# Altium schematic sheet Power_Distribution.SchDoc(Power_Distribution)
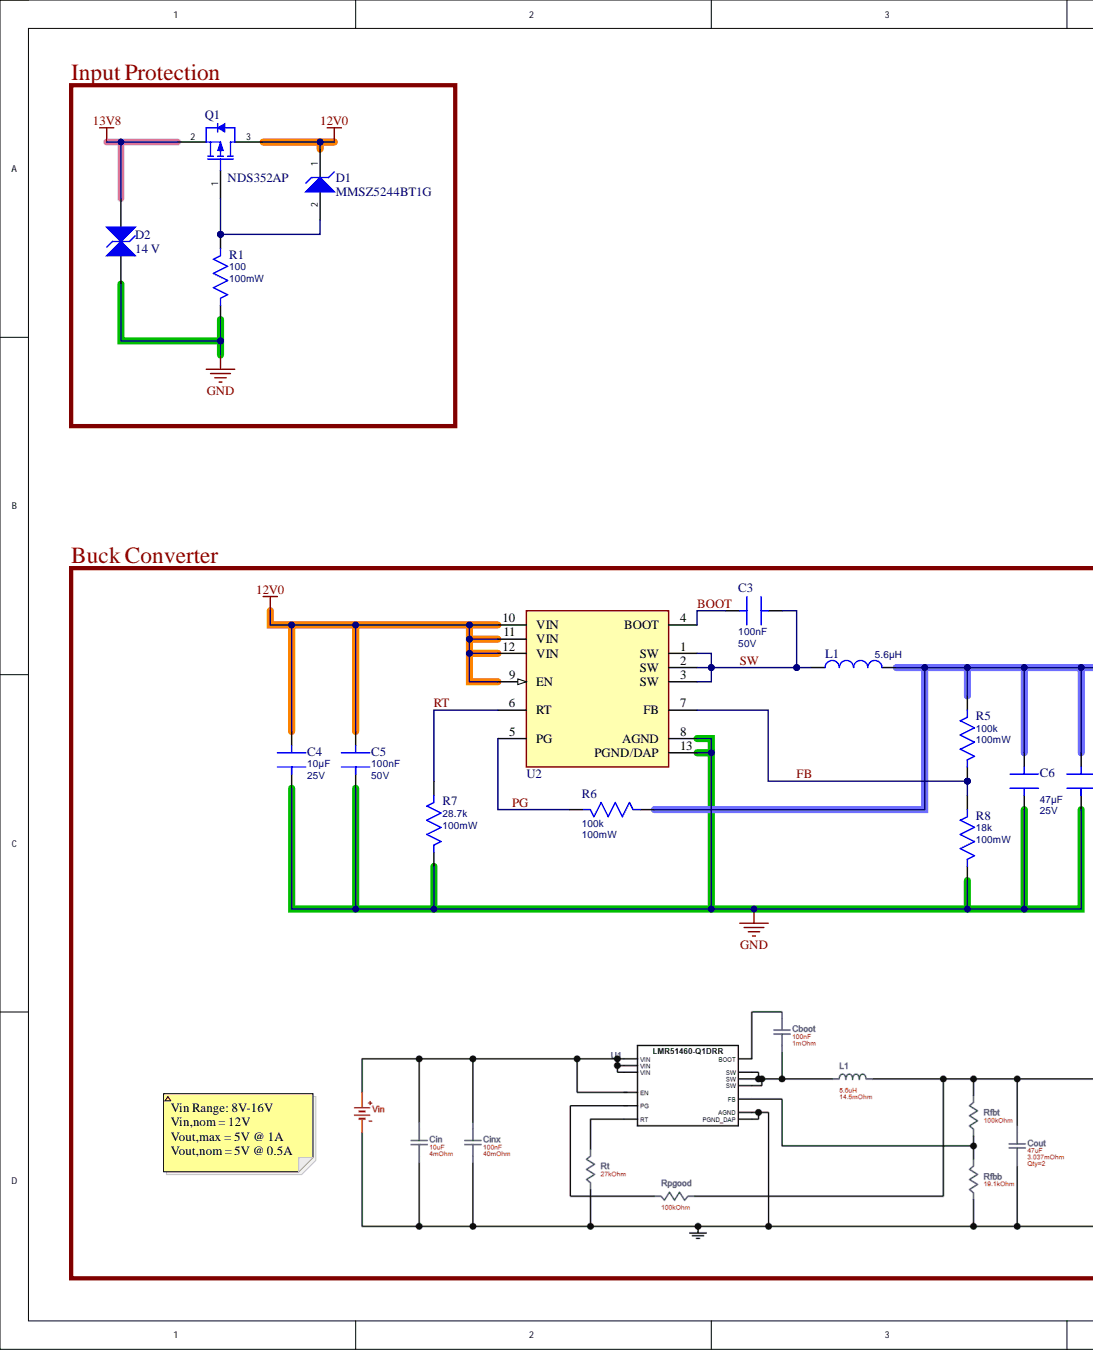
[diagram p0: Power_Distribution.SchDoc, left]
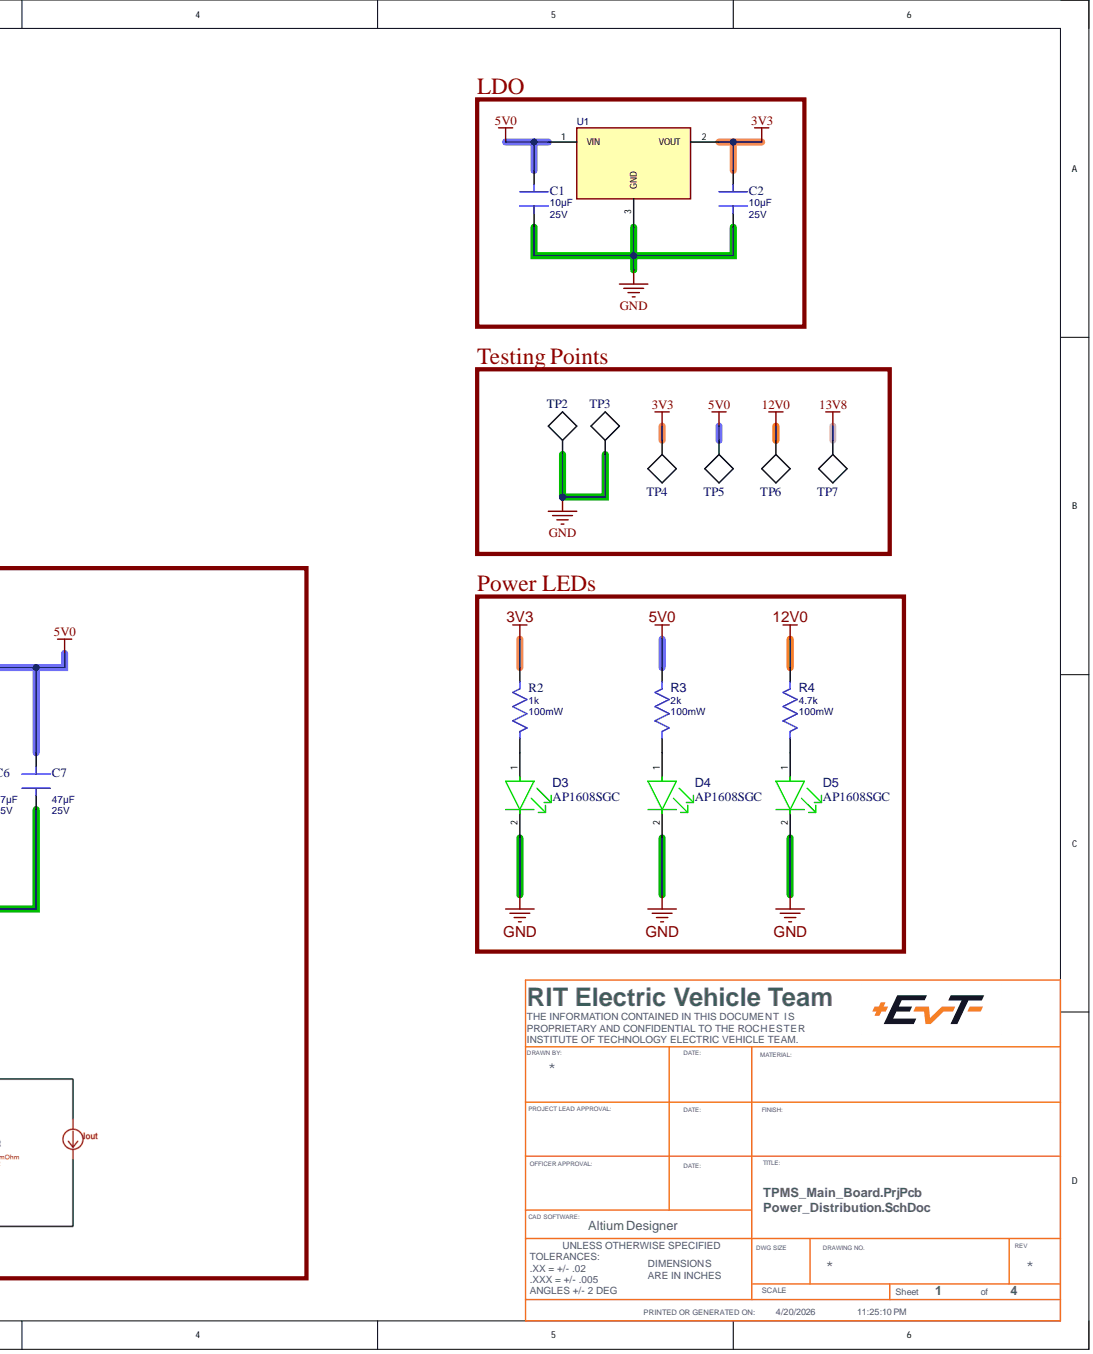
[diagram p1: Power_Distribution.SchDoc, right]

## Parts
C1  Capacitance: 10µF  Voltage Rating: 25V  (hidden comment: CAP 10uF 25V X5R 20% 0603)
C2  Capacitance: 10µF  Voltage Rating: 25V  (hidden comment: CAP 10uF 25V X5R 20% 0603)
C3  Capacitance: 100nF  Voltage Rating: 50V  (hidden comment: CAP 100nF 50V X7R 10% 0603 CAP)
C4  Capacitance: 10µF  Voltage Rating: 25V  (hidden comment: CAP 10uF 25V X5R 20% 0603)
C5  Capacitance: 100nF  Voltage Rating: 50V  (hidden comment: CAP 100nF 50V X7R 10% 0603 CAP)
C6  Capacitance: 47µF  Voltage Rating: 25V  (hidden comment: CAP 47U0 25V 20%)
C7  Capacitance: 47µF  Voltage Rating: 25V  (hidden comment: CAP 47U0 25V 20%)
D1  MMSZ5244BT1G
D2  Standoff Voltage: 14 V  (hidden comment: SMAJ14CA-E3/61)
D3  AP1608SGC
D4  AP1608SGC
D5  AP1608SGC
L1  Inductance: 5.6µH  (hidden comment: SRP6540-5R6M)
Q1  NDS352AP
R1  Power Rating: 100mW  (hidden comment: RES 100R 100mW 1%)
R2  Power Rating: 100mW  Resistance: 1k  (hidden comment: RES 1k 100m 0.1% 0603)
R3  Power Rating: 100mW  Resistance: 2k  (hidden comment: RES 2K 100mW 1%)
R4  Power Rating: 100mW  Resistance: 4.7k  (hidden comment: RES 4k7 100mW 1%)
R5  Power Rating: 100mW  Resistance: 100k  (hidden comment: RES 100k 100m 0.1% 0603)
R6  Power Rating: 100mW  Resistance: 100k  (hidden comment: RES 100k 100m 0.1% 0603)
R7  Power Rating: 100mW  Resistance: 28.7k  (hidden comment: RES 28k7 100mW 1%)
R8  Power Rating: 100mW  Resistance: 18k  (hidden comment: RES 18k 100m 1% 0603)
TP2  50mil TP  (value not drawn)
TP3  50mil TP  (value not drawn)
TP4  50mil TP  (value not drawn)
TP5  50mil TP  (value not drawn)
TP6  50mil TP  (value not drawn)
TP7  50mil TP  (value not drawn)
U1  AP7375-33SA-7  (value not drawn)
U2  LMR51460SQDRRRQ1  (value not drawn)

## Nets
3V3: C2.1 R2.1 TP4.1 U1.2
5V0: C1.1 C6.1 C7.1 L1.2 R3.1 R5.1 R6.2 TP5.1 U1.1
12V0: C4.1 C5.1 D1.1 Q1.3 R4.1 TP6.1 U2.9(EN) U2.10(VIN) U2.11(VIN) U2.12(VIN)
13V8: D2.2 Q1.2 TP7.1
GND: C1.2 C2.2 C4.2 C5.2 C6.2 C7.2 D2.1 D3.2 D4.2 D5.2 R1.2 R7.2 R8.2 TP2.1 TP3.1 U1.3 U2.8(AGND) U2.13(PGND/DAP)
BOOT: C3.1 U2.4(BOOT)
FB: R5.2 R8.1 U2.7(FB)
PG: R6.1 U2.5(PG)
RT: R7.1 U2.6(RT)
SW: C3.2 L1.1 U2.1(SW) U2.2(SW) U2.3(SW)
NetD1_2: D1.2 Q1.1 R1.1
NetD3_1: D3.1 R2.2
NetD4_1: D4.1 R3.2
NetD5_1: D5.1 R4.2

## Library data of the parts
C1 C2 C4 = CMP-00654-1: Multilayer Ceramic Capacitors MLCC – SMD/SMT; TDK; C1608X5R1E106M080AC; 10 µF; 0603; 25 V; 25 V; Polarized (Y/N): N; Component Kind: Standard; JLC Basic Part (Y/N): N; JLC Part #: C2168289; MPN: C1608X5R1E106M080AC; Package: 0603; Temperature Coefficient: X5R; Tolerance: 20%; Library Name: RIT-EVT-Vault
C3 C5 = CMP-00408-16: 50V 100nF X7R ±10% 0603 Multilayer Ceramic Capacitors MLCC - SMD/SMT ROHS; MPN: CC0603KRX7R9BB104; Polarized (Y/N): N; Component Kind: Standard; JLC Basic Part (Y/N): Y; JLC Part #: C14663; Package: 0603; Temperature Coefficient: X7R; Tolerance: 10%; Library Name: RIT-EVT-Vault
C6 C7 = CMP-00684-2: Multilayer Ceramic Capacitors MLCC – SMD/SMT; TDK; C3216X5R1E476M160AC; 47 µF; 1206; 25 V; 25 V; Polarized (Y/N): N; Component Kind: Standard; JLC Basic Part (Y/N): N; JLC Part #: C2167787; MPN: C3216X5R1E476M160AC; Package: 1206; Temperature Coefficient: N/A; Tolerance: 20%; Library Name: RIT-EVT-Vault
D1 = CMP-00560-2: Diode Zener Single 14V 5% 500mW Automotive 2-Pin SOD-123 T/R; Current Rating: 9mA; Forward Voltage: 900mV; Component Kind: Standard; JLC Basic Part (Y/N): N; JLCPCB Part #: C242286; MPN: MMSZ5244BT1G; Package: SOD-123; Zener Voltage: 14V; Library Name: RIT-EVT-Vault
D2 = CMP-00583-1: SMAJ Series 400 W 14 V Bi-Directional SMT TRANSZORB® TVS Diode - DO-214AC; Breakdown Voltage: 15.6V; Clamping Voltage: 23.2V; Current Rating: 17.2 A; Component Kind: Standard; JLC Basic Part (Y/N): N; JLCPCB Part #: C250392; MPN: SMAJ14CA-E3/61; Package: SMA(DO-214AC); Library Name: RIT-EVT-Vault
D3-D5 = CMP-00486-2: LED Uni-Color Green 565nm 2-Pin Chip LED / 1.6X0.8mm SMD CHIP LED LAMP; Current Rating: 25mA; Forward Voltage: 2.5V; Reverse Breakdown Voltage: 5V; Wavelength: 568nm; Color: Green; Component Kind: Standard; JLC Basic Part (Y/N): N; JLCPCB Part #: C9900169279; MPN: AP1608SGC; Package: 0603; Library Name: RIT-EVT-Vault
L1 = CMP-00685-1: Inductor Power Shielded/Molded Wirewound 5.6uH 20% 100KHz Powdered Iron 5.5A 38mOhm DCR T/R; Core Material: Ferrite; ESR: 38m; Polarized (Y/N): N; Saturation Current: 6A; Component Kind: Standard; Heating Current: 5.5A; JLC Basic Part (Y/N): N; JLC Part #: C2041376; MPN: SRP6540-5R6M; Package: Nonstandard; SRF: 100kHz; Tolerance: 20%; Library Name: RIT-EVT-Vault
Q1 = CMP-00492-1: P-Channel Logic Level Enhancement Mode Field Effect Transistor -30V, -0.9A, 300mΩ; Rated Drain/Collector Current: 900mA; Transistor Type: P; Component Kind: Standard; JLC Basic Part: N; JLCPCB Part: C3290037; JLCPCB Part #: C3290037; MPN: NDS352AP; Package: SuperSOT-7; Rated Drain-Source or Collector-Emitter Voltage: -30V; Library Name: RIT-EVT-Vault
R1 = CMP-00434-3: -55℃~+155℃ 100mW 100Ω 75V Thick Film Resistor ±1% ±100ppm/℃ 0603 Chip Resistor - Surface Mount ROHS; MPN: CRCW0603100RFKEA; Polarized (Y/N): N; Resistance: 100; Component Kind: Standard; JLC Basic Part (Y/N): Y; JLCPCB Part #: C22775; Package: 0603; Tolerance: 1%; Voltage Rating: 75V; Library Name: RIT-EVT-Vault
R2 = CMP-00544-3: -55℃~+155℃ 100mW 1kΩ 75V Thin Film Resistor ±0.1% ±25ppm/℃ 0603 Chip Resistor - Surface Mount ROHS; MPN: RT0603BRD071KL; Polarized (Y/N): N; Component Kind: Standard; JLC Basic Part (Y/N): N; JLCPCB Part #: C110776; Package: 0603; Tolerance: 0.1%; Voltage Rating: 75V; Library Name: RIT-EVT-Vault
R3 = CMP-00474-1: -55℃~+155℃ 100mW 2kΩ 75V Thick Film Resistor ±1% ±100ppm/℃ 0603 Chip Resistor - Surface Mount ROHS; MPN: 0603WAF2001T5E; Polarized (Y/N): N; Component Kind: Standard; JLC Basic Part (Y/N): Y; JLCPCB Part #: C22975; Package: 0603; Tolerance: 1%; Voltage Rating: 75V; Library Name: RIT-EVT-Vault
R4 = CMP-00425-4: -55℃~+155℃ 100mW 4.7kΩ 75V Thick Film Resistor ±1% ±100ppm/℃ 0603 Chip Resistor - Surface Mount ROHS; MPN: 0603WAF4701T5E; Polarized (Y/N): N; Component Kind: Standard; JLC Basic Part (Y/N): N; JLCPCB Part #: C23162; Package: 0603; Tolerance: 1%; Voltage Rating: 75V; Library Name: RIT-EVT-Vault
R5 R6 = CMP-00538-2: -55℃~+155℃ 100kΩ 100mW 75V Thin Film Resistor ±0.1% ±25ppm/℃ 0603 Chip Resistor - Surface Mount ROHS; MPN: RT0603BRD07100KL; Polarized (Y/N): N; Component Kind: Standard; JLC Basic Part (Y/N): N; JLCPCB Part #: C122538; Package: 0603; Tolerance: 0.1%; Voltage Rating: 75V; Library Name: RIT-EVT-Vault
R7 = CMP-00610-2: 100mW Thick Film Resistors 75V ±100ppm/℃ ±1% 28.7kΩ 0603 Chip Resistor - Surface Mount ROHS; MPN: 0603WAF2872T5E; Polarized (Y/N): N; Component Kind: Standard; JLC Basic Part (Y/N): N; JLCPCB Part #: C22928; Package: 0603; Tolerance: 1%; Voltage Rating: 75V; Library Name: RIT-EVT-Vault
R8 = CMP-00557-2: -55℃~+155℃ 100mW 18kΩ 75V Thick Film Resistor ±1% ±100ppm/℃ 0603 Chip Resistor - Surface Mount ROHS; MPN: 0603WAF1802T5E; Polarized (Y/N): N; Component Kind: Standard; JLC Basic Part (Y/N): Y; JLCPCB Part #: C25810; Package: 0603; Tolerance: 1%; Voltage Rating: 75V; Library Name: RIT-EVT-Vault
TP2-TP7 = CMP-00563-2: 50 mil TP; Component Kind: Standard; JLC BASIC Part (Y/N): N/A; JLCPCB Part #: N/A; MPN: N/A; Package: N/A; Library Name: RIT-EVT-Vault
U1 = CMP-00423-1: Fixed 3V3 LDO. LDO Regulator Pos 3.3V 0.3A 3-Pin SOT-23 T/R; JLPCB Part #: C5944186; Component Kind: Standard; JLC Basic Part (Y/N): N; MPN: AP7375-33SA-7; Package: SOT-23; Library Name: RIT-EVT-Vault
U2 = CMP-00473-3: -40℃~+125℃@(TA) 200kHz~1MHz 25uA 4V~36V 6A 800mV~28V Adjustable Buck Buck Built-In WSON-12(3x3) DC-DC Converters ROHS; JLPCB Part #: C41802645; Component Kind: Standard; JLC Basic Part (Y/N): Y; MPN: LMR51460SQDRRRQ1; Package: WSON-12(3x3); Library Name: RIT-EVT-Vault
